# Altium schematic sheet FT2232H.SchDoc(FT2232H)
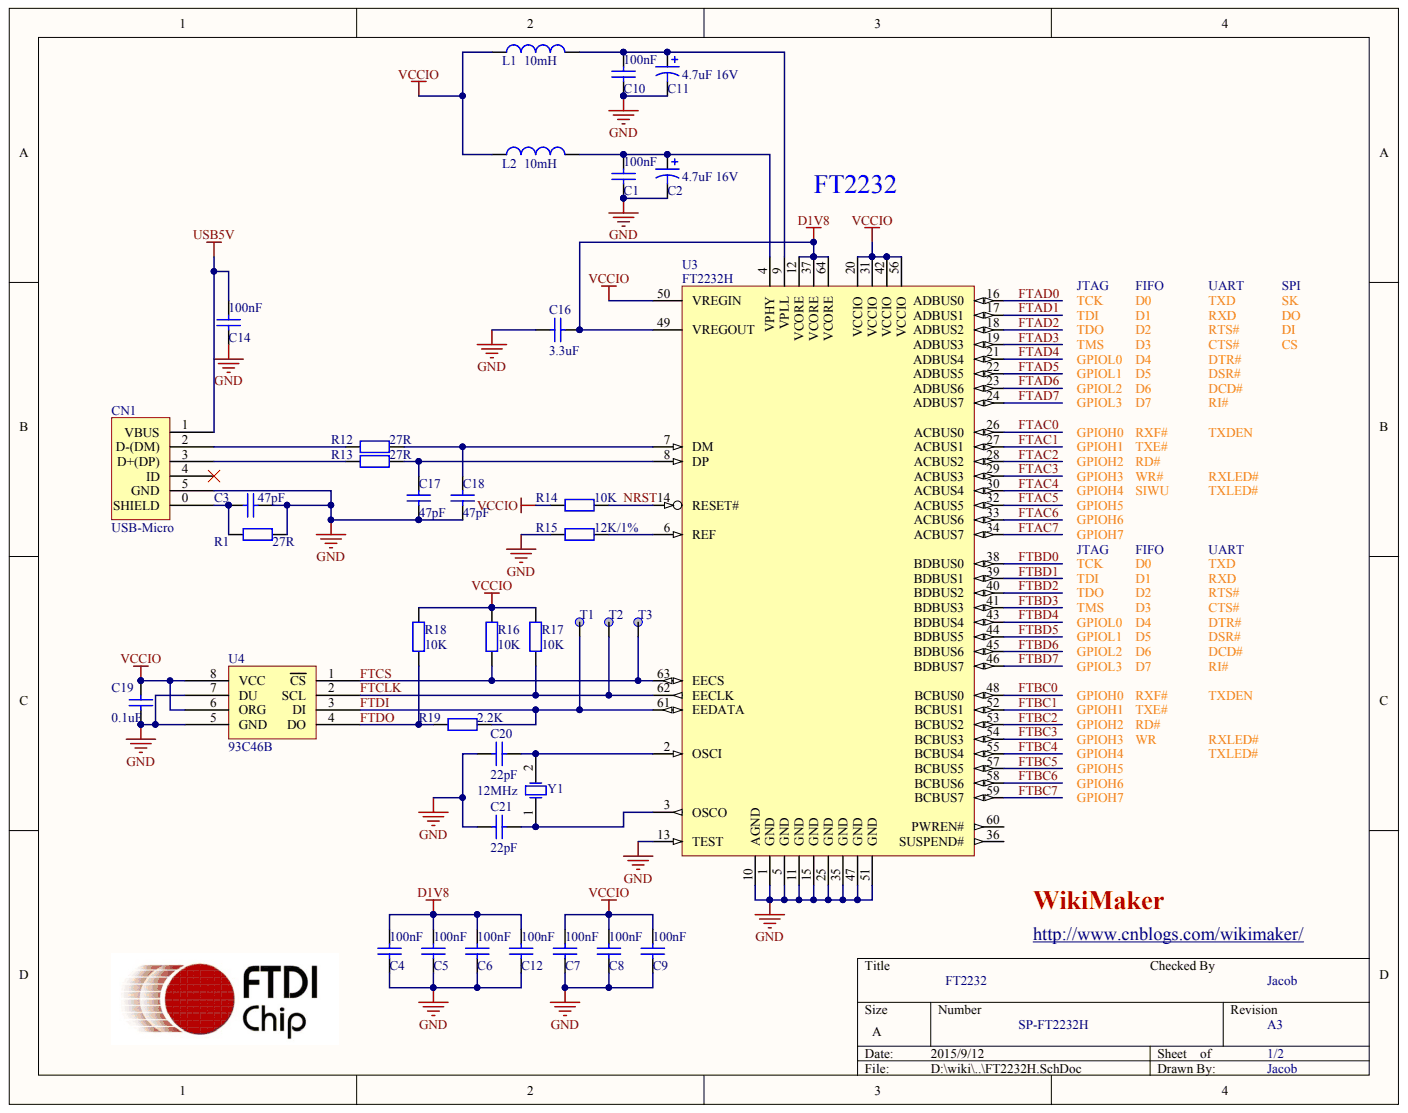
[diagram p0: FT2232H.SchDoc]

## Parts
C1  100nF
C2  4.7uF 16V
C3  47pF
C4  100nF
C5  100nF
C6  100nF
C7  100nF
C8  100nF
C9  100nF
C10  100nF
C11  4.7uF 16V
C12  100nF
C14  100nF
C16  3.3uF
C17  47pF
C18  47pF
C19  0.1uF
C20  22pF
C21  22pF
CN1  USB-Micro
L1  value 10mH  (hidden comment: Inductor)
L2  value 10mH  (hidden comment: Inductor)
R1  27R
R12  27R
R13  27R
R14  10K
R15  12K/1%
R16  10K
R17  10K
R18  10K
R19  2.2K
T1  TP  (value not drawn)
T2  TP  (value not drawn)
T3  TP  (value not drawn)
U3  FT2232H
U4  93C46B
Y1  12MHz

## Nets
D1V8: C4.2 C5.2 C6.2 C12.2 C16.1 U3.12(VCORE) U3.37(VCORE) U3.49(VREGOUT) U3.64(VCORE)
GND: C1.1 C2.2 C3.2 C4.1 C5.1 C6.1 C7.1 C8.1 C9.1 C10.1 C11.2 C12.1 C14.1 C16.2 C17.1 C18.1 C19.2 C20.1 C21.1 CN1.5(GND) R1.1 R15.2 U3.1(GND) U3.5(GND) U3.10(AGND) U3.11(GND) U3.13(TEST) U3.15(GND) U3.25(GND) U3.35(GND) U3.47(GND) U3.51(GND) U4.5(GND) U4.7(DU)
USB5V: C14.2 CN1.1(VBUS)
VCCIO: C7.2 C8.2 C9.2 C19.1 L1.1 L2.1 R14.2 R16.1 R17.1 R18.1 U3.20(VCCIO) U3.31(VCCIO) U3.42(VCCIO) U3.50(VREGIN) U3.56(VCCIO) U4.6(ORG) U4.8(VCC)
FTAC0: U3.26(ACBUS0)
FTAC1: U3.27(ACBUS1)
FTAC2: U3.28(ACBUS2)
FTAC3: U3.29(ACBUS3)
FTAC4: U3.30(ACBUS4)
FTAC5: U3.32(ACBUS5)
FTAC6: U3.33(ACBUS6)
FTAC7: U3.34(ACBUS7)
FTAD0: U3.16(ADBUS0)
FTAD1: U3.17(ADBUS1)
FTAD2: U3.18(ADBUS2)
FTAD3: U3.19(ADBUS3)
FTAD4: U3.21(ADBUS4)
FTAD5: U3.22(ADBUS5)
FTAD6: U3.23(ADBUS6)
FTAD7: U3.24(ADBUS7)
FTBC0: U3.48(BCBUS0)
FTBC1: U3.52(BCBUS1)
FTBC2: U3.53(BCBUS2)
FTBC3: U3.54(BCBUS3)
FTBC4: U3.55(BCBUS4)
FTBC5: U3.57(BCBUS5)
FTBC6: U3.58(BCBUS6)
FTBC7: U3.59(BCBUS7)
FTBD0: U3.38(BDBUS0)
FTBD1: U3.39(BDBUS1)
FTBD2: U3.40(BDBUS2)
FTBD3: U3.41(BDBUS3)
FTBD4: U3.43(BDBUS4)
FTBD5: U3.44(BDBUS5)
FTBD6: U3.45(BDBUS6)
FTBD7: U3.46(BDBUS7)
FTCLK: R17.2 T2.1 U3.62(EECLK) U4.2(SCL)
FTCS: R16.2 T3.1 U3.63(EECS) U4.1(CS)
FTDI: R19.1 T1.1 U3.61(EEDATA) U4.3(DI)
FTDO: R18.2 R19.2 U4.4(DO)
NRST: R14.1 U3.14(RESET#)
NetC1_2: C1.2 C2.1 L2.2 U3.4(VPHY)
NetC3_1: C3.1 CN1.0(SHIELD) R1.2
NetC10_2: C10.2 C11.1 L1.2 U3.9(VPLL)
NetC17_2: C17.2 R13.1 U3.8(DP)
NetC18_2: C18.2 R12.1 U3.7(DM)
NetC20_2: C20.2 U3.2(OSCI) Y1.2
NetC21_2: C21.2 U3.3(OSCO) Y1.1
NetCN1_2: CN1.2(D-(DM)) R12.2
NetCN1_3: CN1.3(D+(DP)) R13.2
NetR15_1: R15.1 U3.6(REF)

Not connected: CN1 4(ID); U3 36(SUSPEND#) 60(PWREN#)

## Library data of the parts
L1 L2 = (no symbol name): Code_IEC: 1005; Published: 8-Jun-2000; LatestRevisionDate: 29-May-2009; LatestRevisionNote: IPC-7351 Footprint Added.; PackageReference: 0402-A; PackageDescription: Chip Inductor; Code_JEITA: 0402; Publisher: Altium Limited
C1-C12 C14 C16-C21 CN1 R1 R12-R19 T1-T3 U3 U4 Y1 = (no symbol name)
  C1 C4-C10 C12 C14: Published: 8-Jun-2000; LatestRevisionDate: 17-Jul-2002; LatestRevisionNote: Re-released for DXP Platform.; PackageReference: RAD-0.3; PackageDescription: Radial Cap, Thru-Hole; 2 Leads; 0.3 in Pin Spacing; Value: 100nF
  C2 C11: Published: 8-Jun-2000; LatestRevisionDate: 17-Jul-2002; LatestRevisionNote: Re-released for DXP Platform.; PackageReference: RB7.6-15; PackageDescription: Polarized Capacitor (Radial); 2 Leads
  C3 C17 C18: Published: 8-Jun-2000; LatestRevisionDate: 17-Jul-2002; LatestRevisionNote: Re-released for DXP Platform.; PackageReference: RAD-0.3; Value: 47pF
  C16: Published: 8-Jun-2000; LatestRevisionDate: 17-Jul-2002; LatestRevisionNote: Re-released for DXP Platform.; PackageReference: RAD-0.3; Value: 3.3uF
  C19: Published: 8-Jun-2000; LatestRevisionDate: 17-Jul-2002; LatestRevisionNote: Re-released for DXP Platform.; PackageReference: RAD-0.3; Value: 0.1uF
  C20 C21: Published: 8-Jun-2000; LatestRevisionDate: 17-Jul-2002; LatestRevisionNote: Re-released for DXP Platform.; PackageReference: RAD-0.3; Value: 22pF
  R1 R12 R13: Published: 8-Jun-2000; LatestRevisionDate: 17-Jul-2002; LatestRevisionNote: Re-released for DXP Platform.; PackageReference: AXIAL-0.4; Value: 27R
  R14 R16-R18: Published: 8-Jun-2000; LatestRevisionDate: 17-Jul-2002; LatestRevisionNote: Re-released for DXP Platform.; PackageReference: AXIAL-0.4; Value: 10K
  R15: Published: 8-Jun-2000; LatestRevisionDate: 17-Jul-2002; LatestRevisionNote: Re-released for DXP Platform.; PackageReference: AXIAL-0.4; Value: 12K/1%
  R19: Published: 8-Jun-2000; LatestRevisionDate: 17-Jul-2002; LatestRevisionNote: Re-released for DXP Platform.; PackageReference: AXIAL-0.4; Value: 2.2K
  U3: Published: 19-Aug-2010; DatasheetVersion: ver 2.08, May-2010; PackageVersion: 2010; PackageReference: LQFP-64; PackageDescription: 64-Pin Thin Quad Flat Pack, 10 x 10 x 1.4 mm Body, 0.5 mm Pitch; ComponentLink1Description: Manufacturer Link; ComponentLink1URL: http://www.ftdichip.com/Products/ICs/FT2232H.htm; ComponentLink2Description: Datasheet; ComponentLink2URL: http://www.ftdichip.com/Support/Documents/DataSheets/ICs/DS_FT2232H.pdf
  Y1: Published: 8-Jun-2000; LatestRevisionDate: 17-Jul-2002; LatestRevisionNote: Re-released for DXP Platform.; PackageReference: R38
  C1-C12 C14 C16-C21 R1 R12-R19 U3 Y1: Publisher: Altium Limited
